# Altium schematic sheet Decawave.SchDoc
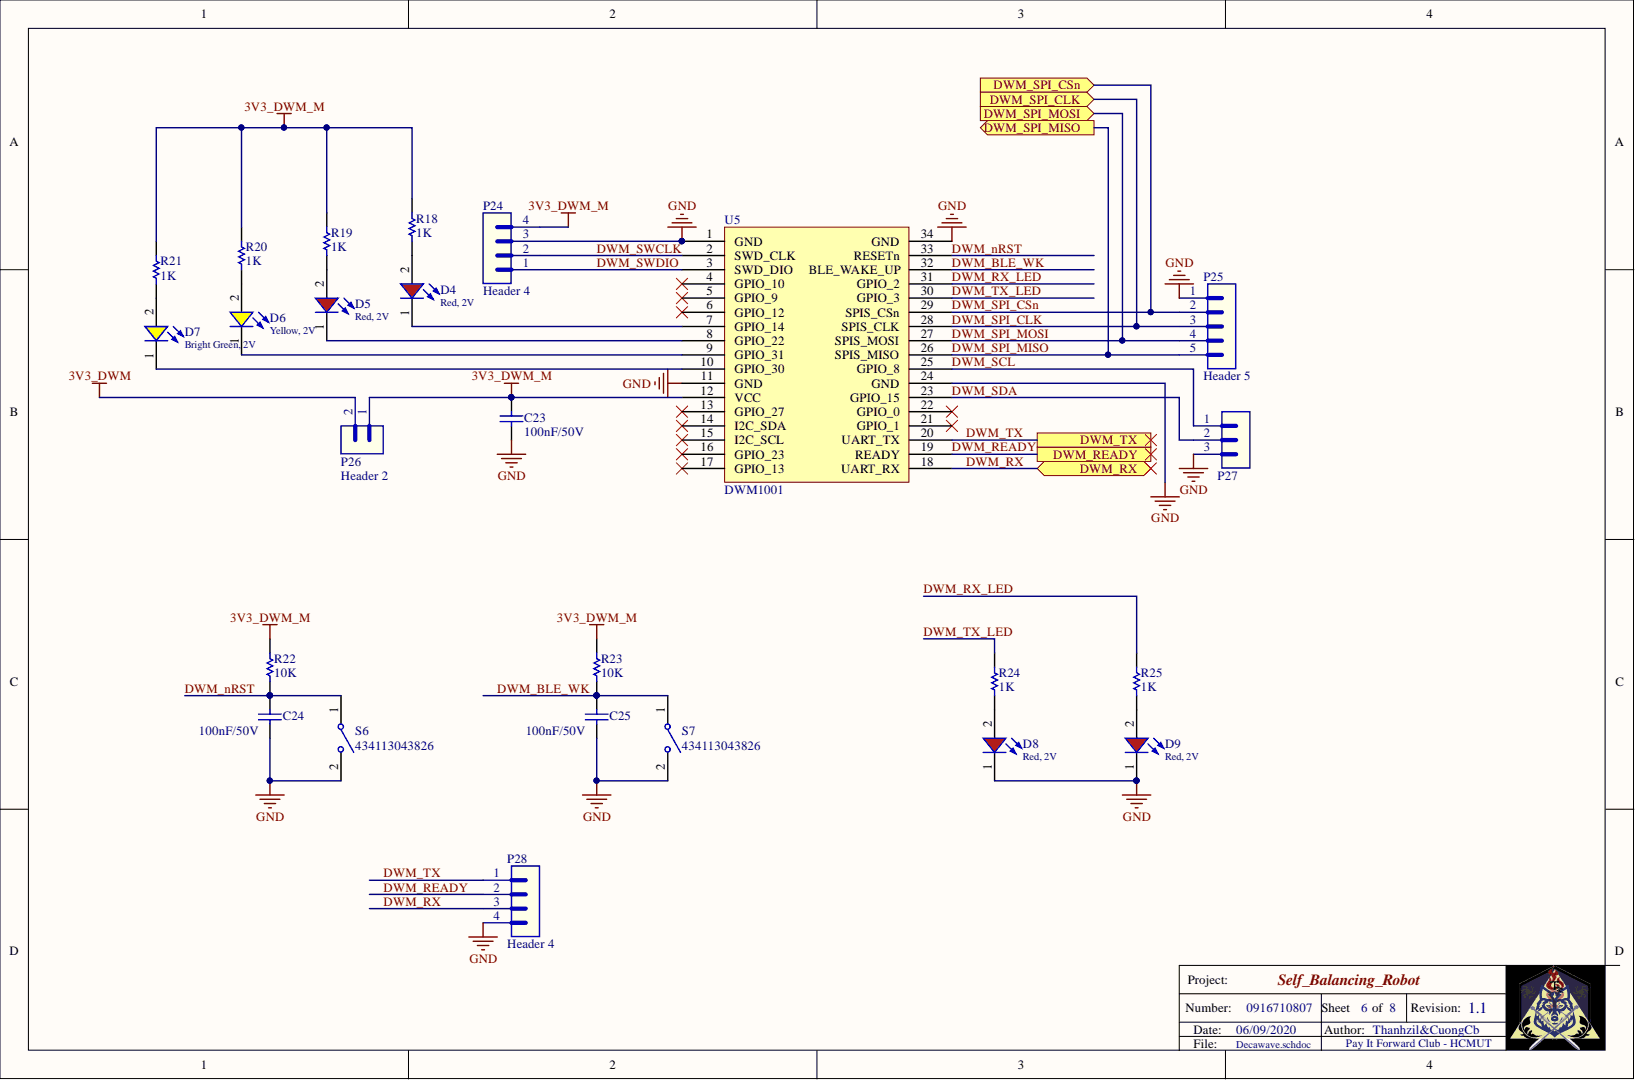
[diagram p0: Decawave.SchDoc]

## Parts
C23  value 100nF/50V  [CAP-SM-0805]  (hidden comment: Cap-100nF-50V-0805)
C24  value 100nF/50V  [CAP-SM-0805]  (hidden comment: Cap-100nF-50V-0805)
C25  value 100nF/50V  [CAP-SM-0805]  (hidden comment: Cap-100nF-50V-0805)
D4  Red, 2V  Forward Voltage typ.: 2V  [WL-SMCW_0805]
D5  Red, 2V  Forward Voltage typ.: 2V  [WL-SMCW_0805]
D6  Yellow, 2V  Forward Voltage typ.: 2V  [WL-SMCW_0805]
D7  Bright Green, 2V  [WL-SMCW_0805]
D8  Red, 2V  Forward Voltage typ.: 2V  [WL-SMCW_0805]
D9  Red, 2V  Forward Voltage typ.: 2V  [WL-SMCW_0805]
P24  Header 4  [JP4]
P25  Header 5  [JP5]
P26  Header 2  [JP2]
P27  Header 3  [JP3]  (value not drawn)
P28  Header 4  [JP4]
R18  value 1K  [RES-SM-0805]  (hidden comment: Res-1K-0805)
R19  value 1K  [RES-SM-0805]  (hidden comment: Res-1K-0805)
R20  value 1K  [RES-SM-0805]  (hidden comment: Res-1K-0805)
R21  value 1K  [RES-SM-0805]  (hidden comment: Res-1K-0805)
R22  value 10K  [RES-SM-0805]  (hidden comment: Res-10k-0805)
R23  value 10K  [RES-SM-0805]  (hidden comment: Res-10k-0805)
R24  value 1K  [RES-SM-0805]  (hidden comment: Res-1K-0805)
R25  value 1K  [RES-SM-0805]  (hidden comment: Res-1K-0805)
S6  434113043826  [434113043826]
S7  434113043826  [434113043826]
U5  DWM1001  [DWM1001]

## Ports
DWM_READY, DWM_RX, DWM_SPI_CLK, DWM_SPI_CSn, DWM_SPI_MISO, DWM_SPI_MOSI, DWM_TX

## Nets
3V3_DWM: P26.2
3V3_DWM_M: C23.2 P24.4 P26.1 R18.2 R19.2 R20.2 R21.2 R22.2 R23.2 U5.12(VCC)
GND: C23.1 C24.2 C25.2 D8.1 D9.1 P24.3 P25.1 P27.3 P28.4 S6.2 S7.2 U5.1(GND) U5.11(GND) U5.24(GND) U5.34(GND)
DWM_BLE_WK: C25.1 R23.1 S7.1 U5.32(BLE_WAKE_UP)
DWM_nRST: C24.1 R22.1 S6.1 U5.33(RESETn)
DWM_READY: P28.2 U5.19(READY) ; port DWM_READY
DWM_RX: P28.3 U5.18(UART_RX) ; port DWM_RX
DWM_RX_LED: R25.2 U5.31(GPIO_2)
DWM_SCL: P27.1 U5.25(GPIO_8)
DWM_SDA: P27.2 U5.23(GPIO_15)
DWM_SPI_CLK: P25.3 U5.28(SPIS_CLK) ; port DWM_SPI_CLK
DWM_SPI_CSn: P25.2 U5.29(SPIS_CSn) ; port DWM_SPI_CSn
DWM_SPI_MISO: P25.5 U5.26(SPIS_MISO) ; port DWM_SPI_MISO
DWM_SPI_MOSI: P25.4 U5.27(SPIS_MOSI) ; port DWM_SPI_MOSI
DWM_SWCLK: P24.2 U5.2(SWD_CLK)
DWM_SWDIO: P24.1 U5.3(SWD_DIO)
DWM_TX: P28.1 U5.20(UART_TX) ; port DWM_TX
DWM_TX_LED: R24.2 U5.30(GPIO_3)
NetD4_1: D4.1 U5.7(GPIO_14)
NetD4_2: D4.2 R18.1
NetD5_1: D5.1 U5.8(GPIO_22)
NetD5_2: D5.2 R19.1
NetD6_1: D6.1 U5.9(GPIO_31)
NetD6_2: D6.2 R20.1
NetD7_1: D7.1 U5.10(GPIO_30)
NetD7_2: D7.2 R21.1
NetD8_2: D8.2 R24.1
NetD9_2: D9.2 R25.1

Not connected: U5 4(GPIO_10) 5(GPIO_9) 6(GPIO_12) 13(GPIO_27) 14(I2C_SDA) 15(I2C_SCL) 16(GPIO_23) 17(GPIO_13) 21(GPIO_1) 22(GPIO_0)

## Library data of the parts
C23-C25 = Cap-100nF-50V-0805: CAP CER 0.1UF 50V Y5V 0805; Manufacturer: Samsung Electro-Mechanics; Manufacturer Part Number: CL21F104ZBCNNNC; Component Kind: Standard; Library Name: Capacitor.SchLib; Local Supplier: thegioiic; Local Supplier Link: https://thegioiic.com/products/tu-100nf-0-1uf-50v-0805; Supplier 1: Digikey; Supplier 1 Link: https://www.digikey.com/product-detail/en/samsung-electro-mechanics/CL21F104ZBCNNNC/1276-1007-1-ND/3889093; Supplier 1 Part Number: 1276-1007-1-ND
D4 D5 D8 D9 = 150080RS75000: WL-SMCW SMT Mono-color Chip LED Waterclear, size 0805, Red, 2V, 140deg; Chip Technology: AlInGaP; Dominant Wavelength typ.: 625nm; Luminous Intensity typ.: 150mcd; Peak Wavelength typ.: 630nm; Component Kind: Standard; ComponentLink1Description: Manufacturer; ComponentLink1URL: http://www.we-online.de; ComponentLink2Description: Datasheet; ComponentLink2URL: https://www.we-online.com/catalog/datasheet/150080RS75000.pdf; Emitting Color: Red; Library Name: LED_150060BS75000_Altium_WL-SMCW_rev20a.intlib; Mounting Technology: SMT; Operating Temperature: -40 to +85degC; Packaging: Tape and Reel; Part Number: 150080RS75000; Published Date: December 2019; Size: 0805; Viewing Angle: 140deg; Publisher: Wurth Elektronik
D6 = 150080YS75000: WL-SMCW SMT Mono-color Chip LED Waterclear, size 0805, Yellow, 2V, 140deg; Chip Technology: AlInGaP; Dominant Wavelength typ.: 590nm; Luminous Intensity typ.: 120mcd; Peak Wavelength typ.: 595nm; Component Kind: Standard; ComponentLink1Description: Manufacturer; ComponentLink1URL: http://www.we-online.de; ComponentLink2Description: Datasheet; ComponentLink2URL: https://www.we-online.com/catalog/datasheet/150080YS75000.pdf; Emitting Color: Yellow; Library Name: LED_150060BS75000_Altium_WL-SMCW_rev20a.intlib; Mounting Technology: SMT; Operating Temperature: -40 to +85degC; Packaging: Tape and Reel; Part Number: 150080YS75000; Published Date: December 2019; Size: 0805; Viewing Angle: 140deg; Publisher: Wurth Elektronik
D7 = 150080VS75000: WL-SMCW SMT Mono-color Chip LED Waterclear, size 0805, Bright Green, 2V, 140deg; Chip Technology: AlInGaP; Dominant Wavelength typ.: 570nm; Luminous Intensity typ.: 40mcd; Peak Wavelength typ.: 572nm; Component Kind: Standard; ComponentLink1Description: Manufacturer; ComponentLink1URL: http://www.we-online.de; ComponentLink2Description: Datasheet; ComponentLink2URL: https://www.we-online.com/catalog/datasheet/150080VS75000.pdf; Emitting Color: Bright Green; Forward Voltage typ.: 2V; Library Name: LED_150060BS75000_Altium_WL-SMCW_rev20a.intlib; Mounting Technology: SMT; Operating Temperature: -40 to +85degC; Packaging: Tape and Reel; Part Number: 150080VS75000; Published Date: December 2019; Size: 0805; Viewing Angle: 140deg; Publisher: Wurth Elektronik
P24 P28 = Header 4: Header, 4-Pin; Component Kind: Standard; LatestRevisionDate: 17-Jul-2002; LatestRevisionNote: Re-released for DXP Platform.; Library Name: Header-connector.SchLib; Publisher: Altium Limited
P25 = Header 5: Header, 5-Pin; Component Kind: Standard; LatestRevisionDate: 17-Jul-2002; LatestRevisionNote: Re-released for DXP Platform.; Library Name: Header-connector.SchLib; Publisher: Altium Limited
P26 = Header 2: Header, 2-Pin; Component Kind: Standard; LatestRevisionDate: 17-Jul-2002; LatestRevisionNote: Re-released for DXP Platform.; Library Name: Header-connector.SchLib; Publisher: Altium Limited
P27 = Header 3: Header, 3-Pin; Component Kind: Standard; LatestRevisionDate: 17-Jul-2002; LatestRevisionNote: Re-released for DXP Platform.; Library Name: Header-connector.SchLib; Publisher: Altium Limited
R18-R21 R24 R25 = Res-1K-0805: RES 1K OHM 1% 1/4W 0805; Manufacturer: Stackpole Electronics Inc; Manufacturer Part Number: RNCP0805FTD1K00; Component Kind: Standard; Library Name: Resistor.SchLib; Local Supplier: thegioiic; Local Supplier Link: https://thegioiic.com/products/dien-tro-1k-ohm-0805-5; Supplier 1: Digikey; Supplier 1 Link: https://www.digikey.com/product-detail/en/stackpole-electronics-inc/RNCP0805FTD1K00/RNCP0805FTD1K00CT-ND/2240568; Supplier 1 Part Number: RNCP0805FTD1K00CT-ND
R22 R23 = Res-10k-0805: RES 10K OHM 1% 1/4W 0805; Manufacturer: Stackpole Electronics Inc; Manufacturer Part Number: RNCP0805FTD10K0; Component Kind: Standard; Library Name: Resistor.SchLib; Local Supplier: thegioiic; Local Supplier Link: https://thegioiic.com/products/dien-tro-10k-ohm-0805-5; Supplier 1: Digikey; Supplier 1 Link: https://www.digikey.com/product-detail/en/stackpole-electronics-inc/RNCP0805FTD10K0/RNCP0805FTD10K0CT-ND/2240601; Supplier 1 Part Number: RNCP0805FTD10K0CT-ND
S6 S7 = 434113043826: WS-TASV J-Bend SMT Tact Switch 6x3.5mm, height 4.3mm, 260gf; Rated Current: 50mA; Rated Voltage: 12VDC; Washable: No; Component Kind: Standard; ComponentLink1Description: Manufacturer; ComponentLink1URL: http://www.we-online.de; ComponentLink2Description: Datasheet; ComponentLink2URL: https://katalog.we-online.com/em/datasheet/434113043826.pdf; Contact Material: Stainless Steel; Contact Plating: Silver; Height: 4.3mm; Library Name: 434113043826_Altium_WS-TASV_rev20a.IntLib; Mounting Technology: SMT; Operating Temperature: -40 to +85degC; Operation Force: 260gf; Packaging: Tape and Reel; Part Number: 434113043826; Published Date: September 2019; Terminal Material: Copper Alloy; Terminal Plating: Silver; Publisher: Wurth Elektronik
U5 = DWM1001: Component Kind: Standard; Library Name: PIFWRoBot.SchLib
